# Altium schematic sheet Controle.SchDoc(Controle)
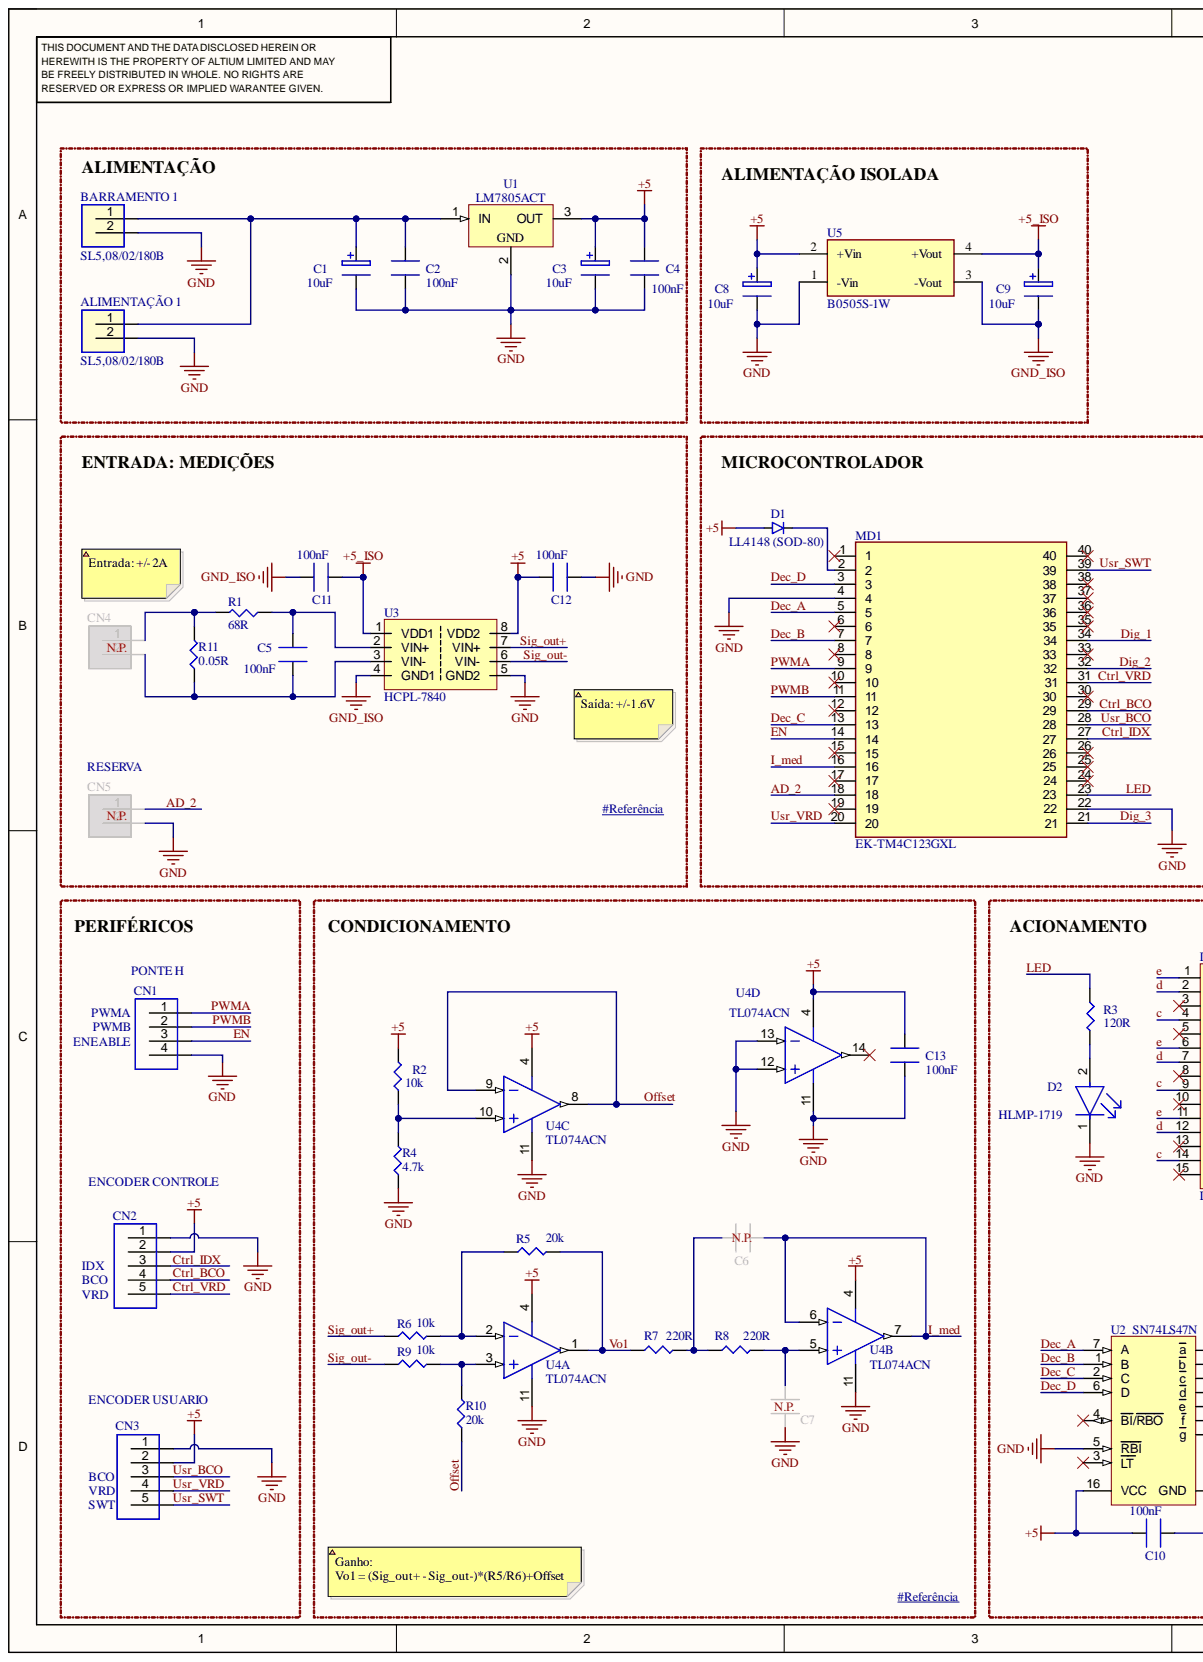
[diagram p0: Controle.SchDoc, left]
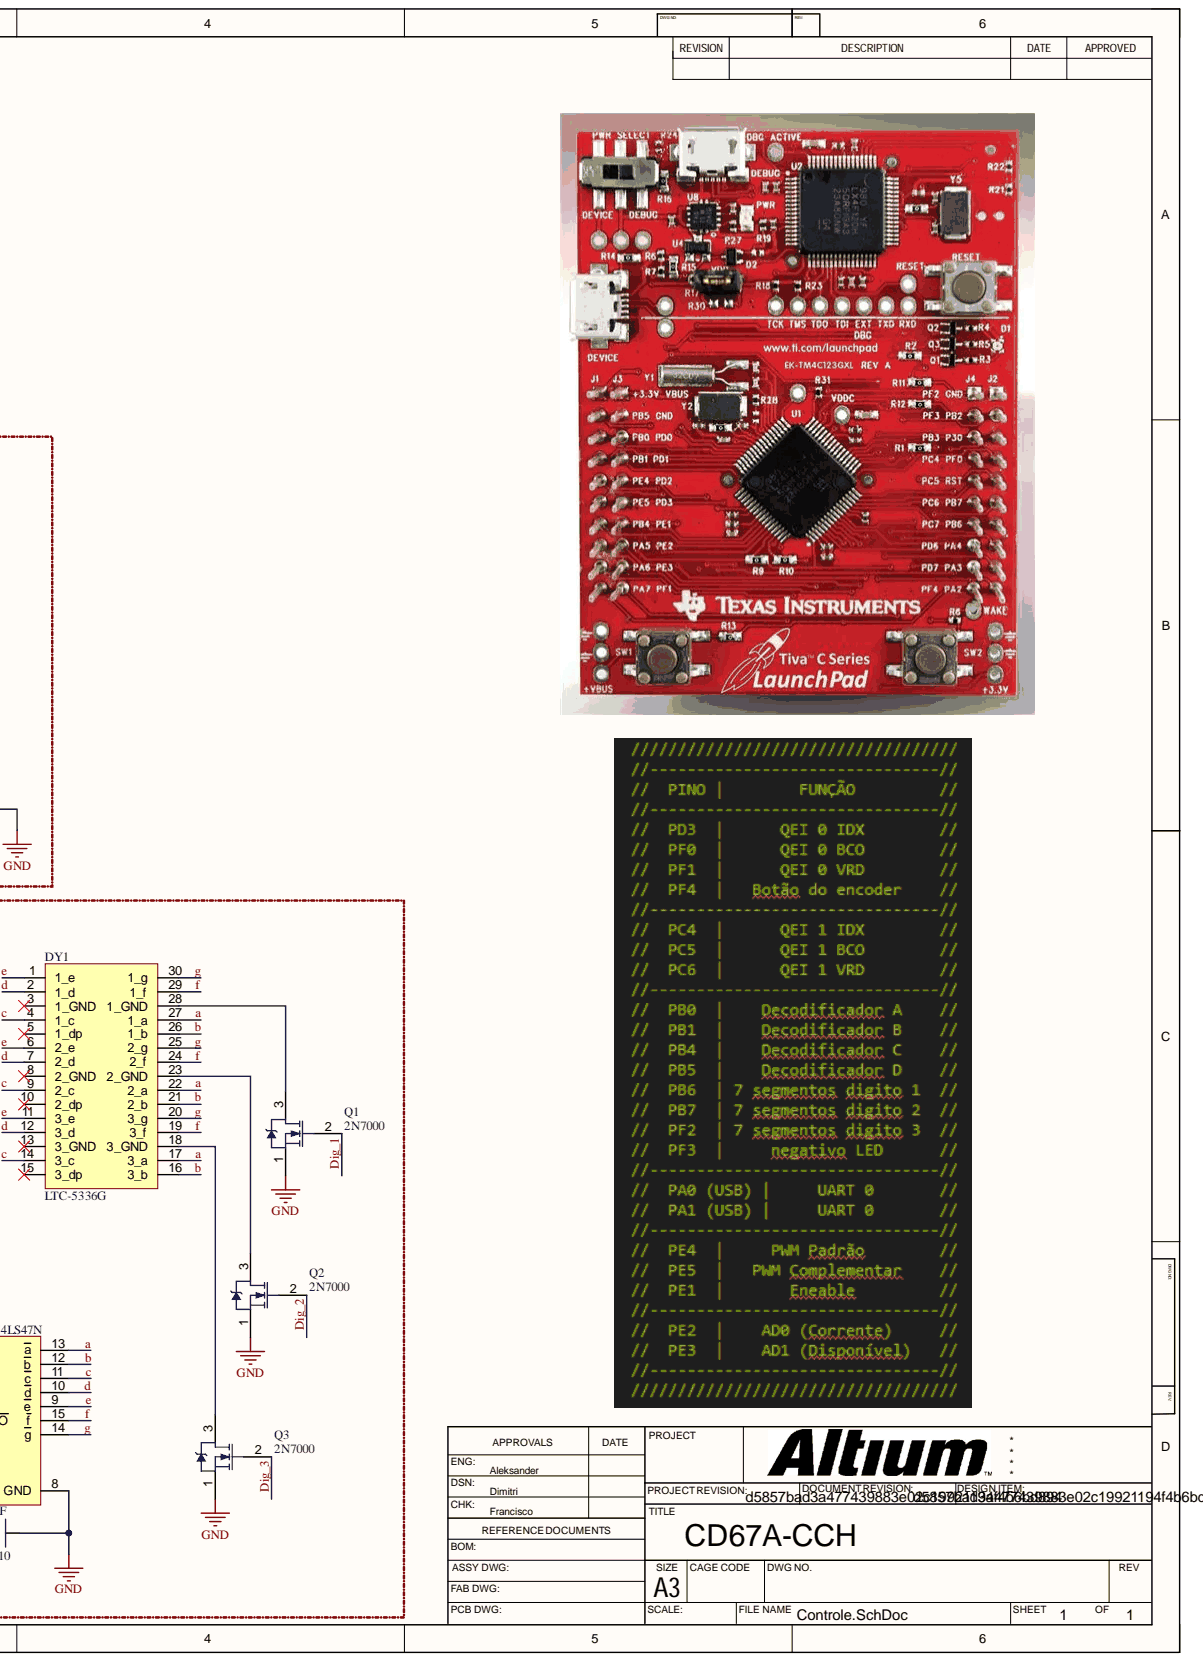
[diagram p1: Controle.SchDoc, right]

## Parts
ALIMENTAÇÃO_1  SL5,08/02/180B  [SL5,08/02/180B]
BARRAMENTO_1  SL5,08/02/180B  [SL5,08/02/180B]
C1  10uF  [cap eletr 8mm]
C2  100nF  [cap cer 5 x 3 (5mm)]
C3  10uF  [cap eletr 8mm]
C4  100nF  [cap cer 5 x 3 (5mm)]
C5  100nF  [cap cer 5 x 3 (5mm)]
C6  100nF  [cap cer 5 x 3 (5mm)]  (value not drawn)
C7  100nF  [cap cer 5 x 3 (5mm)]  (value not drawn)
C8  10uF  [cap eletr 8mm]
C9  10uF  [cap eletr 8mm]
C10  100nF  [cap cer 5 x 3 (5mm)]
C11  100nF  [cap cer 5 x 3 (5mm)]
C12  100nF  [cap cer 5 x 3 (5mm)]
C13  100nF  [cap cer 5 x 3 (5mm)]
CN1  BPSC 4  [BPSC 4]  (value not drawn)
CN2  MCS-05  [MCS-05]  (value not drawn)
CN3  MCS-05  [MCS-05]  (value not drawn)
CN4  STL 1550 02G 3,5V  [STL1550 02G 3,5V]  (value not drawn)
CN5  STL 1550 02G 3,5V  [STL1550 02G 3,5V]  (value not drawn)
D1  LL4148 (SOD-80)  Codigo: LL4148  [SOD-80]
D2  HLMP-1719  [FP-HLMP-1719-MFG]
DY1  LTC-5336G  [3_DIG_DISPLAY]
MD1  EK-TM4C123GXL  [FP-EK-TM4C123GXL-MFG]
Q1  2N7000  [ONSC-TO-92-3-29-11_AM_BL]
Q2  2N7000  [ONSC-TO-92-3-29-11_AM_BL]
Q3  2N7000  [ONSC-TO-92-3-29-11_AM_BL]
R1  value 68R  [SMD-1206-RES]  (hidden comment: ERJ-P08J680V)
R2  value 10k  [SMD-1206-RES]  (hidden comment: ERJ-8ENF1002V)
R3  value 120R  [SMD-1206-RES]  (hidden comment: ERJ-P08J121V)
R4  value 4.7k  [SMD-1206-RES]  (hidden comment: ERJ-8ENF4701V)
R5  value 20k  [SMD-1206-RES]  (hidden comment: ERJ-8GEYJ203V)
R6  value 10k  [SMD-1206-RES]  (hidden comment: ERJ-8ENF1002V)
R7  value 220R  [SMD-1206-RES]  (hidden comment: ERJ-P08J221V)
R8  value 220R  [SMD-1206-RES]  (hidden comment: ERJ-P08J221V)
R9  value 10k  [SMD-1206-RES]  (hidden comment: ERJ-8ENF1002V)
R10  value 20k  [SMD-1206-RES]  (hidden comment: ERJ-8GEYJ203V)
R11  value 0.05R  [SMD-2512-RES]  (hidden comment: ERJ-L1WKJ10CU)
U1  LM7805ACT  [FAIR-TO-220-3]
U2  SN74LS47N  [N0016A]
U3  HCPL-7840  [HCPL-7840-Footprint-1]
U4  TL074ACN  [N0014A]  (parts A,B,C,D)
U5  B0505S-1W  [FP-RFM-0505S-MFG]

## Nets
+5: C3.1 C4.1 C8.1 C10.2 C12.1 C13.1 CN2.2 CN3.2 D1.A R2.2 U1.3 U2.16(VCC) U3.8(VDD2) U4.4(+5) U5.2(+Vin)
+5_ISO: C9.1 C11.1 U3.1(VDD1) U5.4(+Vout)
GND: ALIMENTAÇÃO_1.2 BARRAMENTO_1.2 C1.2 C2.2 C3.2 C4.2 C7.1 C8.2 C10.1 C12.2 C13.2 CN1.4 CN2.1 CN3.1 CN5.2 D2.1 MD1.4 MD1.22 Q1.1 Q2.1 Q3.1 R4.1 U1.2 U2.5(RBI) U2.8(GND) U3.5(GND2) U4.11 U4.12 U4.13 U5.1(-Vin)
GND_ISO: C9.2 C11.2 U3.4(GND1) U5.3(-Vout)
a: DY1.17(3_a) DY1.22(2_a) DY1.27(1_a) U2.13(a)
AD_2: CN5.1 MD1.18
b: DY1.16(3_b) DY1.21(2_b) DY1.26(1_b) U2.12(b)
c: DY1.4(1_c) DY1.9(2_c) DY1.14(3_c) U2.11(c)
Ctrl_BCO: CN2.4 MD1.29
Ctrl_IDX: CN2.3 MD1.27
Ctrl_VRD: CN2.5 MD1.31
d: DY1.2(1_d) DY1.7(2_d) DY1.12(3_d) U2.10(d)
Dec_A: MD1.5 U2.7(A)
Dec_B: MD1.7 U2.1(B)
Dec_C: MD1.13 U2.2(C)
Dec_D: MD1.3 U2.6(D)
Dig_1: MD1.34 Q1.2
Dig_2: MD1.32 Q2.2
Dig_3: MD1.21 Q3.2
e: DY1.1(1_e) DY1.6(2_e) DY1.11(3_e) U2.9(e)
EN: CN1.3 MD1.14
f: DY1.19(3_f) DY1.24(2_f) DY1.29(1_f) U2.15(f)
g: DY1.20(3_g) DY1.25(2_g) DY1.30(1_g) U2.14(g)
I_med: C6.2 MD1.16 U4.6 U4.7
LED: MD1.23 R3.1
Offset: R10.1 U4.8 U4.9
PWMA: CN1.1 MD1.9
PWMB: CN1.2 MD1.11
Sig_out+: R6.1 U3.7(VIN+)
Sig_out-: R9.1 U3.6(VIN-)
Usr_BCO: CN3.3 MD1.28
Usr_SWT: CN3.5 MD1.39
Usr_VRD: CN3.4 MD1.20
Vo1: R5.2 R7.1 U4.1
NetALIMENTAÇÃO 1_1: ALIMENTAÇÃO_1.1 BARRAMENTO_1.1 C1.1 C2.1 U1.1
NetC5_1: C5.1 R1.1 U3.2(VIN+)
NetC5_2: C5.2 CN4.2 R11.1 U3.3(VIN-)
NetC6_1: C6.1 R7.2 R8.1
NetC7_2: C7.2 R8.2 U4.5
NetCN4_1: CN4.1 R1.2 R11.2
NetD1_K: D1.K MD1.2
NetD2_2: D2.2 R3.2
NetDY1_18: DY1.18(3_GND) Q3.3
NetDY1_23: DY1.23(2_GND) Q2.3
NetDY1_28: DY1.28(1_GND) Q1.3
NetR2_1: R2.1 R4.2 U4.10
NetR5_1: R5.1 R6.2 U4.2
NetR9_2: R9.2 R10.2 U4.3

Not connected: DY1 3(1_GND) 5(1_dp) 8(2_GND) 10(2_dp) 13(3_GND) 15(3_dp); MD1 1 6 8 10 12 15 17 19 24 25 26 30 33 35 36 37 38 40; U2 3(LT) 4(BI/RBO); U4 14

## Library data of the parts
ALIMENTAÇÃO_1 BARRAMENTO_1 = SL5,08/02/180B: Conector SL passo 5,08 180º 02 pinos; Descricao: Conector Vertical SL passo 5,08 180º 02 pinos; Fabricante: Conexel; Codigo: SL5,08/02/180B; Component Kind: Standard; Library Name: Lib_Conector.IntLib
C1 C3 C8 C9 = CMP-005-000043-2: Descricao: Capacitor eletrolitico 8mm 105ºC; Fabricante: Epcos; Component Kind: Standard; Component Type: Standard; Design Item ID: cap eletr 8mm; Pin Count: 2; Source: Capacitor.SchLib; Library Name: AKST UTFPR
C2 C4-C7 C10-C13 = CMP-005-000052-2: Codigo: 5HZ104ZADAA; Descricao: Capacitor cerâmico disco; Fabricante: AVX; Valor: 100nF / 50V; Component Kind: Standard; Component Type: Standard; Design Item ID: cap cer 100nF/50V; Pin Count: 2; Source: Capacitor.SchLib; Library Name: AKST UTFPR
CN1 = CMP-125-000003-2: Barra de pinos fileira simples 4 pinos, 180°; Fabricante: Metaltex; Codigo: BPSC 4; Component Kind: Standard; Component Type: Standard; Descricao: Barra de pinos fileira simples 4 pinos; Design Item ID: BPSC 4; Pin Count: 4; Source: Conector.SchLib; Library Name: AKST UTFPR
CN2 CN3 = CMP-125-000035-2: Conector mini header fileira simples 5 pinos; Fabricante: Metaltex; Obs: PN: 046729; Codigo: MCS-05; Component Kind: Standard; Component Type: Standard; Descricao: Conector mini header fileira simples 5 pinos; Design Item ID: MCS-05; Pin Count: 5; Source: Conector.SchLib; Library Name: AKST UTFPR
CN4 CN5 = STL1550 02G 3,5V: Conector STL passo 3,5 180º 02 pinos; Fabricante: Phoenix Mecano; Codigo: STL 1550 02G 3,5V; Component Kind: Standard; Descricao: Conector STL passo 3,5 180º 02 pinos; Library Name: Lib_Conector.IntLib
D1 = CMP-123-000009-1: Diodo de sinal 100V/200mA trr=4nS; Fabricante: Fairchild; Obs: PN: 043840; Component Kind: Standard; Component Type: Standard; Descricao: Diodo de sinal 100V/200mA trr=4nS; Design Item ID: LL4148 (SOD-80); Pin Count: 2; Source: Diodo_SMD.SchLib; Library Name: AKST UTFPR
D2 = CMP-2000-06410-2: LED YELLOW DIFFUSED T-1 T/H; Manufacturer: Broadcom; Forward Current: 2mA; Forward Voltage: 1.8V; Led Orientation: Straight; Luminous Intensity: 2.1mcd; Power Dissipation: 17.5mW; Reverse Voltage: 5V; Viewing Angle: 50°; Wavelength: 585nm; Automotive: No; Category: Diode; Colour: Yellow; Component Kind: Standard; ComponentLink2Description: Datasheet; ComponentLink2URL: https://docs.broadcom.com/docs/AV02-1557EN; Device Class L1: Optoelectronics; Device Class L2: LEDs; Device Class L3: unset; Digikey Description: LED YELLOW DIFFUSED T-1 T/H; Lead Free: Yes; Library Name: Altium Content Vault; Manufacturer Part Number: HLMP-1719; Mouser Description: Standard LEDs - Through Hole Yellow Diffused 585nm 2.1mcd; Octopart: https://octopart.com/hlmp-1719-broadcom-71130405; Package: 0; RoHS: TRUE; Temperature: -40°C to +100°C; Temperature Range High: +100°C; Temperature Range Low: -40°C
DY1 = CMP-00001-3: Smart/Normal 7 Seg Numeric LED Display, 3-Character, Green, 13.2mm; Channels: 3; Forward Current: 25mA; Forward Voltage: 2.8V; Color: Green; Color@wavelength: Green; Component Kind: Standard; Display Height: 13.2mm; JESD-609 Code: e0; Max Operating Temperature: 105°C; Min Operating Temperature: -35°C; Number of Characters: 3; Optoelectronic Device Type: SMART/NORMAL 7 SEG NUMERIC LED DISPLAY; Reach SVHC Compliant: Yes; RoHS Compliant: No; Terminal Finish: Tin; Library Name: AKST UTFPR
MD1 = CMP-031-00007-1: Development kits/Accessories; Component Kind: Standard; Library Name: AKST UTFPR
Q1-Q3 = CMP-013-00020-4: Small Signal MOSFET, 200 mA, 60 V, N-Channel, 3-Pin TO-92, Bulk Box; Manufacturer: Fairchild Semiconductor; Elements: 1; Input Capacitance: 50pF; Nominal Dual Supply Voltage: 60V; Nominal Vgs: 2.1V; Package Version: Rev. AM; Power: 400mW; Rds On Max: 5Ohm; Case/Package: TO-92; Component Kind: Standard; Datasheet URL: http://www.onsemi.com/pub_link/Collateral/2N7000-D.PDF; Datasheet Version: Rev. 8, 04/2011; Max Operating Temperature: 150°C; Min Operating Temperature: -55°C; Mounting Technology: TH; Package Description: 3-Pin Transistor Outline, Body 4.825 x 3.685 mm, Pitch 1.27 mm; Package Quantity: 2000; Package Reference: TO-92-3-29-11_AM_BL; Packaging: Bulk; Pins: 3; RoHS Compliant: True; Library Name: AKST UTFPR
R1 = CMP-258-001121-1: RES SMD 68 OHM 5% 2/3W 1206; Manufacturer: Panasonic Electronic Components; @ qty: 1500; Factory Stock: 0; Minimum Quantity: 1; Power (Watts): 0.667W, 2/3W; Temperature Coefficient: ±200ppm/°C; Unit Price (USD): 0.02178; Component Kind: Standard; Component Type: Standard; Composition: Thick Film; Datasheets: https://industrial.panasonic.com/cdbs/www-data/pdf/RDA0000/AOA0000C243.pdf; Design Item ID: ERJ-P08J680V; Digi-Key Part Number: P68ALCT-ND; Failure Rate: -; Features: Automotive AEC-Q200, Pulse Withstanding; Height - Seated (Max): 0.028" (0.70mm); Image: //media.digikey.com/Renders/Panasonic%20Renders/ERJ-1206%20Pkg.jpg; Number of Terminations: 2; Operating Temperature: -55°C ~ 155°C; Package / Case: 1206 (3216 Metric); Packaging: Cut Tape (CT); Part Number: ERJ-P08J680V; Part Status: Active; Pin Count: 2; Quantity Available: 5067; Series: ERJ; Size / Dimension: 0.126" L x 0.063" W (3.20mm x 1.60mm); Source: Panasonic_ERJ_1206.SchLib; Supplier Device Package: 1206; Tolerance: ±5%; Library Name: AKST UTFPR
R2 R6 R9 = CMP-258-000186-1: RES SMD 10K OHM 1% 1/4W 1206; Manufacturer: Panasonic Electronic Components; @ qty: 1500; Factory Stock: 0; Minimum Quantity: 1; Power (Watts): 0.25W, 1/4W; Temperature Coefficient: ±100ppm/°C; Unit Price (USD): 0.00933; Component Kind: Standard; Component Type: Standard; Composition: Thick Film; Datasheets: http://industrial.panasonic.com/www-cgi/jvcr13pz.cgi?E+PZ+3+AOA0002+ERJ8ENF1002V+7+WW; Design Item ID: ERJ-8ENF1002V; Digi-Key Part Number: P10.0KFCT-ND; Failure Rate: -; Features: Automotive AEC-Q200; Height - Seated (Max): 0.028" (0.70mm); Image: //media.digikey.com/Renders/Panasonic%20Renders/ERJ-1206%20Pkg.jpg; Number of Terminations: 2; Operating Temperature: -55°C ~ 155°C; Package / Case: 1206 (3216 Metric); Packaging: Cut Tape (CT); Part Number: ERJ-8ENF1002V; Part Status: Active; Pin Count: 2; Quantity Available: 1108398; Series: ERJ; Size / Dimension: 0.126" L x 0.063" W (3.20mm x 1.60mm); Source: Panasonic_ERJ_1206.SchLib; Supplier Device Package: 1206; Tolerance: ±1%; Library Name: AKST UTFPR
R3 = CMP-258-001033-1: RES SMD 120 OHM 5% 2/3W 1206; Manufacturer: Panasonic Electronic Components; @ qty: 1500; Factory Stock: 0; Minimum Quantity: 1; Power (Watts): 0.667W, 2/3W; Temperature Coefficient: ±200ppm/°C; Unit Price (USD): 0.02178; Component Kind: Standard; Component Type: Standard; Composition: Thick Film; Datasheets: https://industrial.panasonic.com/cdbs/www-data/pdf/RDA0000/AOA0000C243.pdf; Design Item ID: ERJ-P08J121V; Digi-Key Part Number: P120ALCT-ND; Failure Rate: -; Features: Automotive AEC-Q200, Pulse Withstanding; Height - Seated (Max): 0.028" (0.70mm); Image: //media.digikey.com/Renders/Panasonic%20Renders/ERJ-1206%20Pkg.jpg; Number of Terminations: 2; Operating Temperature: -55°C ~ 155°C; Package / Case: 1206 (3216 Metric); Packaging: Cut Tape (CT); Part Number: ERJ-P08J121V; Part Status: Active; Pin Count: 2; Quantity Available: 9695; Series: ERJ; Size / Dimension: 0.126" L x 0.063" W (3.20mm x 1.60mm); Source: Panasonic_ERJ_1206.SchLib; Supplier Device Package: 1206; Tolerance: ±5%; Library Name: AKST UTFPR
R4 = CMP-258-000573-1: RES SMD 4.7K OHM 1% 1/4W 1206; Manufacturer: Panasonic Electronic Components; @ qty: 1500; Factory Stock: 0; Minimum Quantity: 1; Power (Watts): 0.25W, 1/4W; Temperature Coefficient: ±100ppm/°C; Unit Price (USD): 0.00933; Component Kind: Standard; Component Type: Standard; Composition: Thick Film; Datasheets: http://industrial.panasonic.com/www-cgi/jvcr13pz.cgi?E+PZ+3+AOA0002+ERJ8ENF4701V+7+WW; Design Item ID: ERJ-8ENF4701V; Digi-Key Part Number: P4.70KFCT-ND; Failure Rate: -; Features: Automotive AEC-Q200; Height - Seated (Max): 0.028" (0.70mm); Image: //media.digikey.com/Renders/Panasonic%20Renders/ERJ-1206%20Pkg.jpg; Number of Terminations: 2; Operating Temperature: -55°C ~ 155°C; Package / Case: 1206 (3216 Metric); Packaging: Cut Tape (CT); Part Number: ERJ-8ENF4701V; Part Status: Active; Pin Count: 2; Quantity Available: 213244; Series: ERJ; Size / Dimension: 0.126" L x 0.063" W (3.20mm x 1.60mm); Source: Panasonic_ERJ_1206.SchLib; Supplier Device Package: 1206; Tolerance: ±1%; Library Name: AKST UTFPR
R5 R10 = CMP-258-000816-1: RES SMD 20K OHM 5% 1/4W 1206; Manufacturer: Panasonic Electronic Components; @ qty: 1500; Factory Stock: 0; Minimum Quantity: 1; Power (Watts): 0.25W, 1/4W; Temperature Coefficient: ±200ppm/°C; Unit Price (USD): 0.00700; Component Kind: Standard; Component Type: Standard; Composition: Thick Film; Datasheets: http://industrial.panasonic.com/www-cgi/jvcr13pz.cgi?E+PZ+3+AOA0001+ERJ8GEYJ203V+7+WW; Design Item ID: ERJ-8GEYJ203V; Digi-Key Part Number: P20KECT-ND; Failure Rate: -; Features: Automotive AEC-Q200; Height - Seated (Max): 0.028" (0.70mm); Image: //media.digikey.com/Renders/Panasonic%20Renders/ERJ-1206%20Pkg.jpg; Number of Terminations: 2; Operating Temperature: -55°C ~ 155°C; Package / Case: 1206 (3216 Metric); Packaging: Cut Tape (CT); Part Number: ERJ-8GEYJ203V; Part Status: Active; Pin Count: 2; Quantity Available: 114774; Series: ERJ; Size / Dimension: 0.126" L x 0.063" W (3.20mm x 1.60mm); Source: Panasonic_ERJ_1206.SchLib; Supplier Device Package: 1206; Tolerance: ±5%; Library Name: AKST UTFPR
R7 R8 = CMP-258-001062-1: RES SMD 220 OHM 5% 2/3W 1206; Manufacturer: Panasonic Electronic Components; @ qty: 1500; Factory Stock: 0; Minimum Quantity: 1; Power (Watts): 0.667W, 2/3W; Temperature Coefficient: ±200ppm/°C; Unit Price (USD): 0.02178; Component Kind: Standard; Component Type: Standard; Composition: Thick Film; Datasheets: https://industrial.panasonic.com/cdbs/www-data/pdf/RDA0000/AOA0000C243.pdf; Design Item ID: ERJ-P08J221V; Digi-Key Part Number: P220ALCT-ND; Failure Rate: -; Features: Automotive AEC-Q200, Pulse Withstanding; Height - Seated (Max): 0.028" (0.70mm); Image: //media.digikey.com/Renders/Panasonic%20Renders/ERJ-1206%20Pkg.jpg; Number of Terminations: 2; Operating Temperature: -55°C ~ 155°C; Package / Case: 1206 (3216 Metric); Packaging: Cut Tape (CT); Part Number: ERJ-P08J221V; Part Status: Active; Pin Count: 2; Quantity Available: 24072; Series: ERJ; Size / Dimension: 0.126" L x 0.063" W (3.20mm x 1.60mm); Source: Panasonic_ERJ_1206.SchLib; Supplier Device Package: 1206; Tolerance: ±5%; Library Name: AKST UTFPR
R11 = CMP-257-000497-1: RES SMD 0.1 OHM 5% 1W 2512; Manufacturer: Panasonic Electronic Components; @ qty: 1500; Factory Stock: 0; Minimum Quantity: 1; Temperature Coefficient: ±100ppm/°C; Unit Price (USD): 0.37635; Component Kind: Standard; Component Type: Standard; Composition: Thick Film; Datasheets: https://industrial.panasonic.com/cdbs/www-data/pdf/RDA0000/AOA0000C239.pdf; Design Item ID: ERJ-L1WKJ10CU; Digi-Key Part Number: P100TCT-ND; Failure Rate: -; Features: Automotive AEC-Q200, Current Sense; Height - Seated (Max): 0.047" (1.20mm); Image: //media.digikey.com/Renders/Panasonic%20Renders/ERJ-2512%20Pkg.jpg; Number of Terminations: 2; Operating Temperature: -55°C ~ 125°C; Package / Case: 2512 (6432 Metric); Packaging: Cut Tape (CT); Part Number: ERJ-L1WKJ10CU; Part Status: Active; Pin Count: 2; Power (Watts): 1W; Quantity Available: 20661; Series: ERJ; Size / Dimension: 0.252" L x 0.126" W (6.40mm x 3.20mm); Source: Panasonic_ERJ_2512.SchLib; Supplier Device Package: 2512; Tolerance: ±5%; Library Name: AKST UTFPR
U1 = CMP-2000-04937-1: Positive Voltage Regulator, 5 V, 1 A, 0 to 125 degC, 3-Pin TO-220, RoHS, Tube; Manufacturer: Fairchild; Dropout Voltage Measurement Current: 1A; Line Regulation: 50mV; Load Regulation: 100mV; Maximum Input Voltage: 35V; Number of Outputs: 1; Output Type: Fixed; Output Voltage: 5V; Quiescent Current: 6mA; Regulator Function: Linear; Typical Dropout Voltage @ Current: 2V@1A; Component Kind: Standard; Datasheet URL: https://datasheet.ciiva.com/5816/1359194-5816420.pdf; Datasheet Version: Rev. 1.0.1, 05/2006; Dimensions: 10.67x4.83x16.51mm; Height: 16.51mm; Length: 10.67mm; Library Name: Altium Content Vault; Manufacturer URL: https://www.fairchildsemi.com/; Maximum Operating Temperature: +125degC; Maximum Output Current: 1A; Minimum Operating Temperature: 0degC; Mounting Type: ThroughHole; Package Description: 3-Pin Through Hole Device, Body 10 x 4.5 mm, Pitch 2.54 mm; Package Reference: TO-220; Package Type: TO-220; Package URL: https://www.fairchildsemi.com/package-drawings/TO/TO220B03.pdf; Pin Count: 3; Polarity: Positive; Series: LM78xx; Width: 4.83mm
U2 = CMP-0388-00303-2: BCD-to-7-Segment Decoder / Driver, 4.75 to 5.25 V, 0 to 70 degC, 16-pin DIP (N16), Pb-Free (RoHS); Manufacturer: Texas Instruments; Rating: Catalog; SubFamily: Discrete Segment LED Driver; Vin(Max)(V): 5.25; Vin(Min)(V): 4.75; Code_JEDEC: MS-001; Component Kind: Standard; ComponentLink1Description: Manufacturer URL; ComponentLink1URL: http://www.ti.com/; ComponentLink2Description: Datasheet; ComponentLink2URL: http://www.ti.com/general/docs/lit/getliterature.tsp?genericPartNumber=SN74LS47&fileType=pdf; ComponentLink3Description: Package Specification; ComponentLink3URL: http://www.ti.com/litv/pdf/mpdi002c; DatasheetVersion: SDLS111; Eco-Plan: Pb-Free (RoHS); Library Name: Altium Content Vault; Mounting Technology: Through Hole; PackageDescription: 16-Pin Plastic In-Line, Body 19.305 x 6.35 mm, Pitch 2.54 mm; PackageReference: N0016A; PackageVersion: 4040049/E; PartNumber: SN74LS47N
U3 = CMP-031-00006-1: Isolation Amplifier, 1 Func, 3750V Isolation-Min, 100MHz Band Width, CMOS, PDIP8; Channels: 1; Common Mode Voltage-Max: 2.8V; Isolation Voltage-Min: 3.75kV; Nominal Supply Voltage: 5V; Package Body Material: Plastic; Package Equivalence Code: DIP8,.3; Qualification Status: Not Qualified; Supply Voltage Limit-Max: 5.5V; Surface Mount: No; Time @ Peak Reflow Temperature-Max (s): 30s; Voltage Gain-Max: 8.4; Voltage Gain-Min: 7.6; Amplifier Type: ISOLATION AMPLIFIER; Bandwidth (3dB)-Nom: 100MHz; Case/Package: DIP; Component Kind: Standard; Height - Seated (Max): 4.7mm; JESD-30 Code: R-PDIP-T8; JESD-609 Code: e0; Length: 9.8mm; Max Operating Temperature: 85°C; Min Operating Temperature: -40°C; Moisture Sensitivity Level: 1; Package Shape: Rectangular; Package Style: IN-LINEMeter; Peak Reflow Temperature (Cel): 245°C; Pins: 8; Power Supplies: 5V; Reach SVHC Compliant: Yes; RoHS Compliant: No; Technology: CMOS; Temperature Grade: INDUSTRIAL; Terminal Finish: Tin; Terminal Form: Through Hole; Terminal Pitch: 2.54mm; Terminal Position: DUAL; Width: 7.62mm; Library Name: AKST UTFPR
U4 = CMP-0015-00134-2: Low-Noise JFET-Input Operational Amplifier, 7 to 36 V, 0 to 70 degC, 14-pin DIP (N14), Pb-Free (RoHS); Manufacturer: Texas Instruments; CMRR(Min)(dB): 75; GBW(Typ)(MHz): 3; IIB(Max)(pA): 200; Iq per channel(Max)(mA): 2.5; Number of Channels: 4; Offset Drift(Typ)(uV/C): 18; Rating: Catalog; Slew Rate(Typ)(V/us): 13; Sub Family: General Purpose Amplifier, High Supply Voltage Amplifier (>=30V); Total Supply Voltage(Max)(+5V is 5, +/-5V is 10): 36; Total Supply Voltage(Min)(+5V is 5, +/-5V is 10): 7; Vio (25C)(Max)(mV): 6; Code_JEDEC: MS-001; Component Kind: Standard; ComponentLink1Description: Manufacturer URL; ComponentLink1URL: http://www.ti.com/; ComponentLink2Description: Datasheet; ComponentLink2URL: http://www.ti.com/lit/ds/symlink/tl074a.pdf; ComponentLink3Description: Package Specification; ComponentLink3URL: http://www.ti.com/lit/ml/mpdi002c/mpdi002c.pdf; DatasheetVersion: SLOS080J; Eco-Plan: Pb-Free (RoHS); Library Name: Altium Content Vault; Mounting Technology: Through Hole; PackageDescription: 14-Pin Plastic In-Line, Body 19.305 x 6.35 mm, Pitch 2.54 mm; PackageReference: N0014A; PackageVersion: 4040049/E, 12/2002; PartNumber: TL074ACN; Vn at 1kHz(Typ)(nV/rtHz): 18
U5 = CMP-019-00049-1: DC-DC Unregulated Power Supply Module, 1 Output, 1W, Hybrid; Component Kind: Standard; Library Name: AKST UTFPR
